# Altium schematic sheet Sheet1.SchDoc
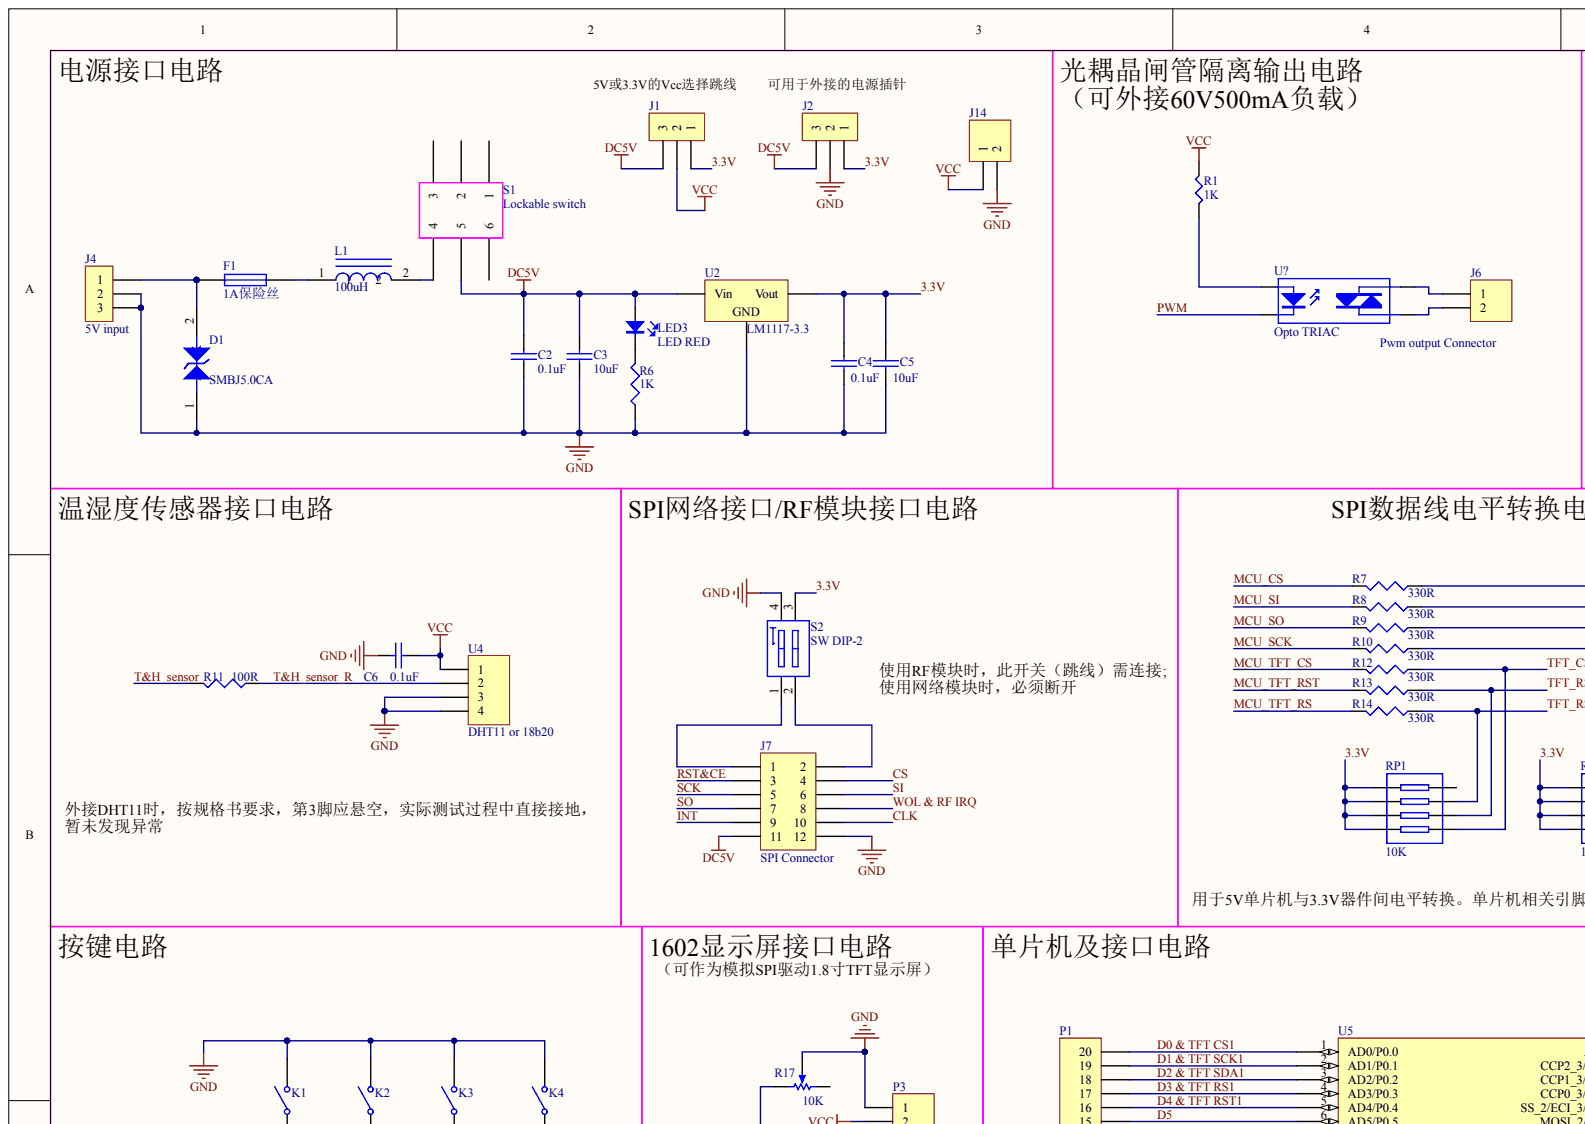
[diagram p0: Sheet1.SchDoc, top left]
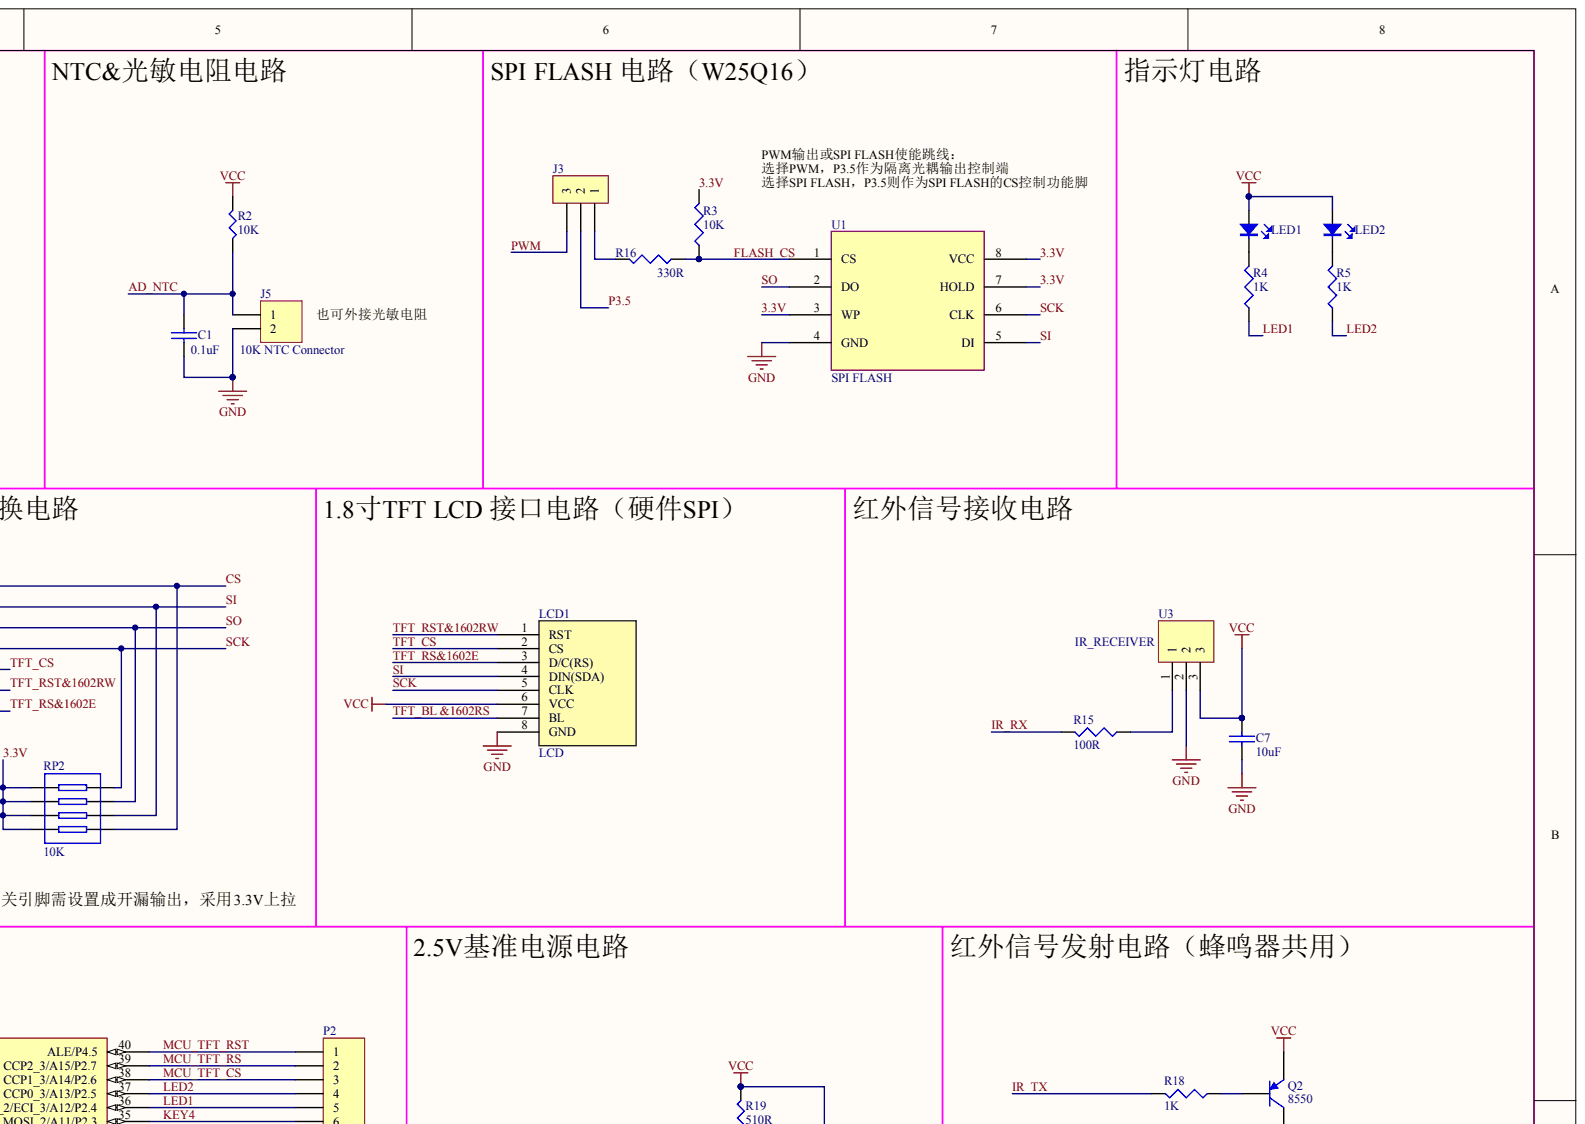
[diagram p1: Sheet1.SchDoc, top right]
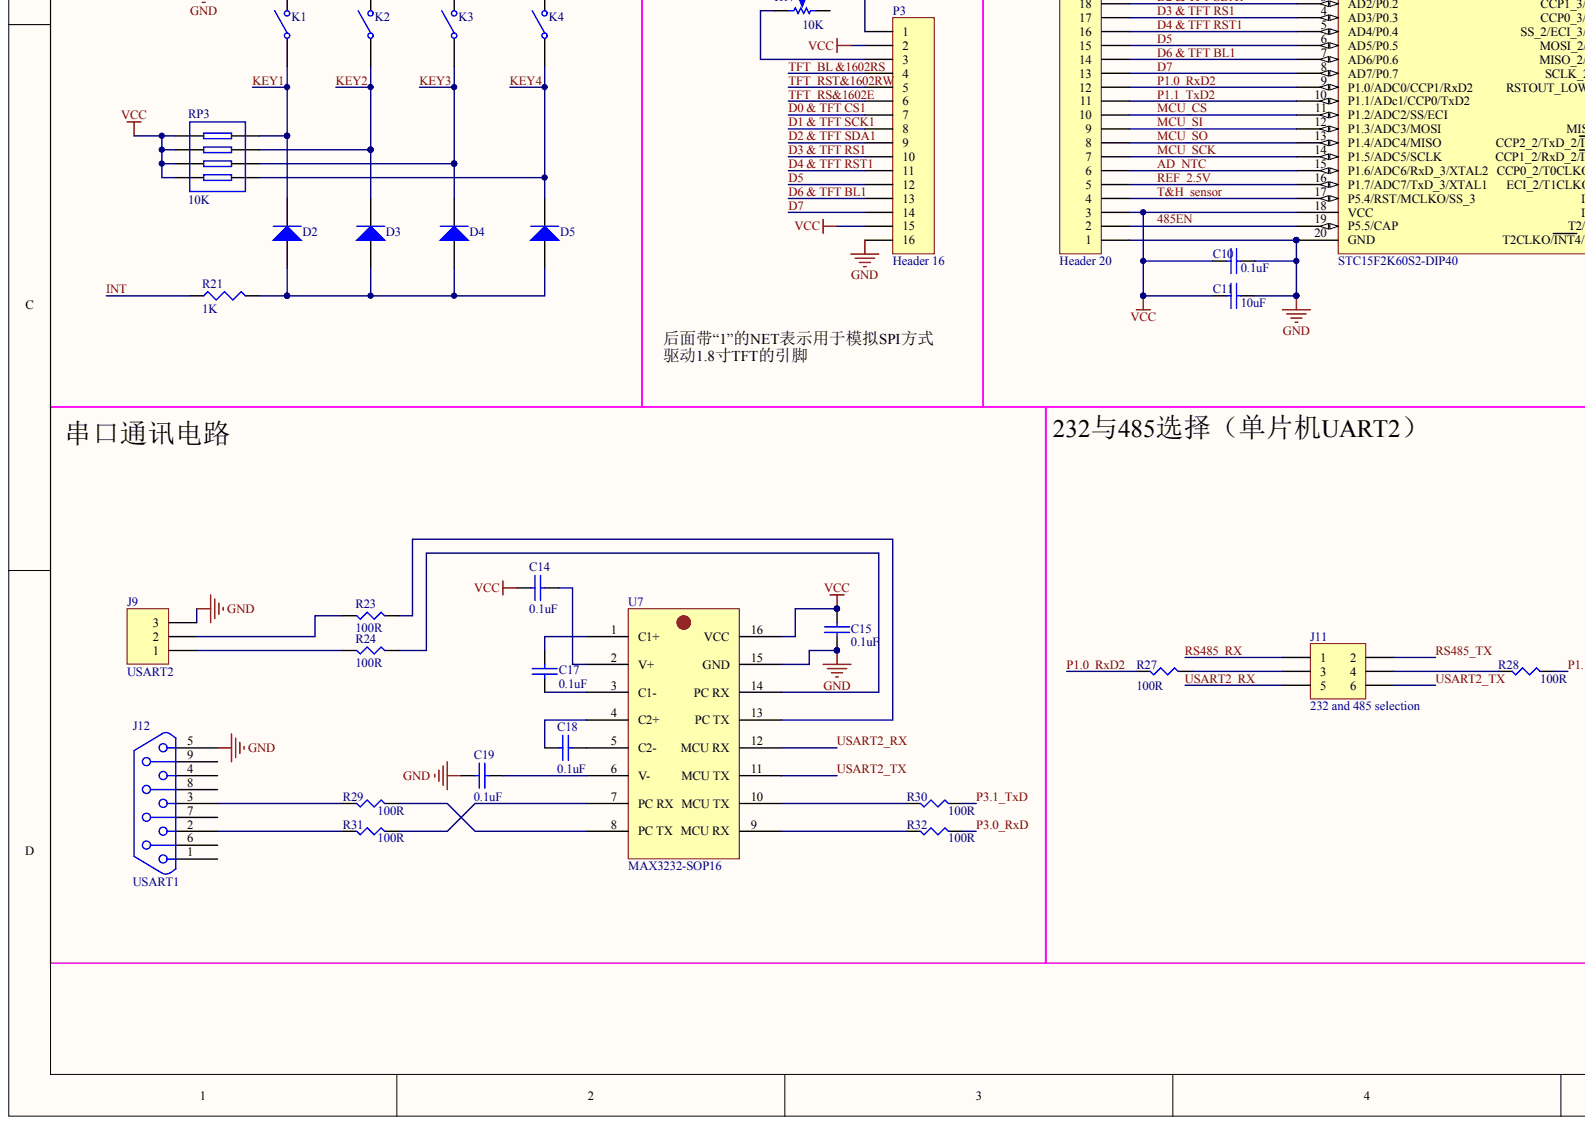
[diagram p2: Sheet1.SchDoc, bottom left]
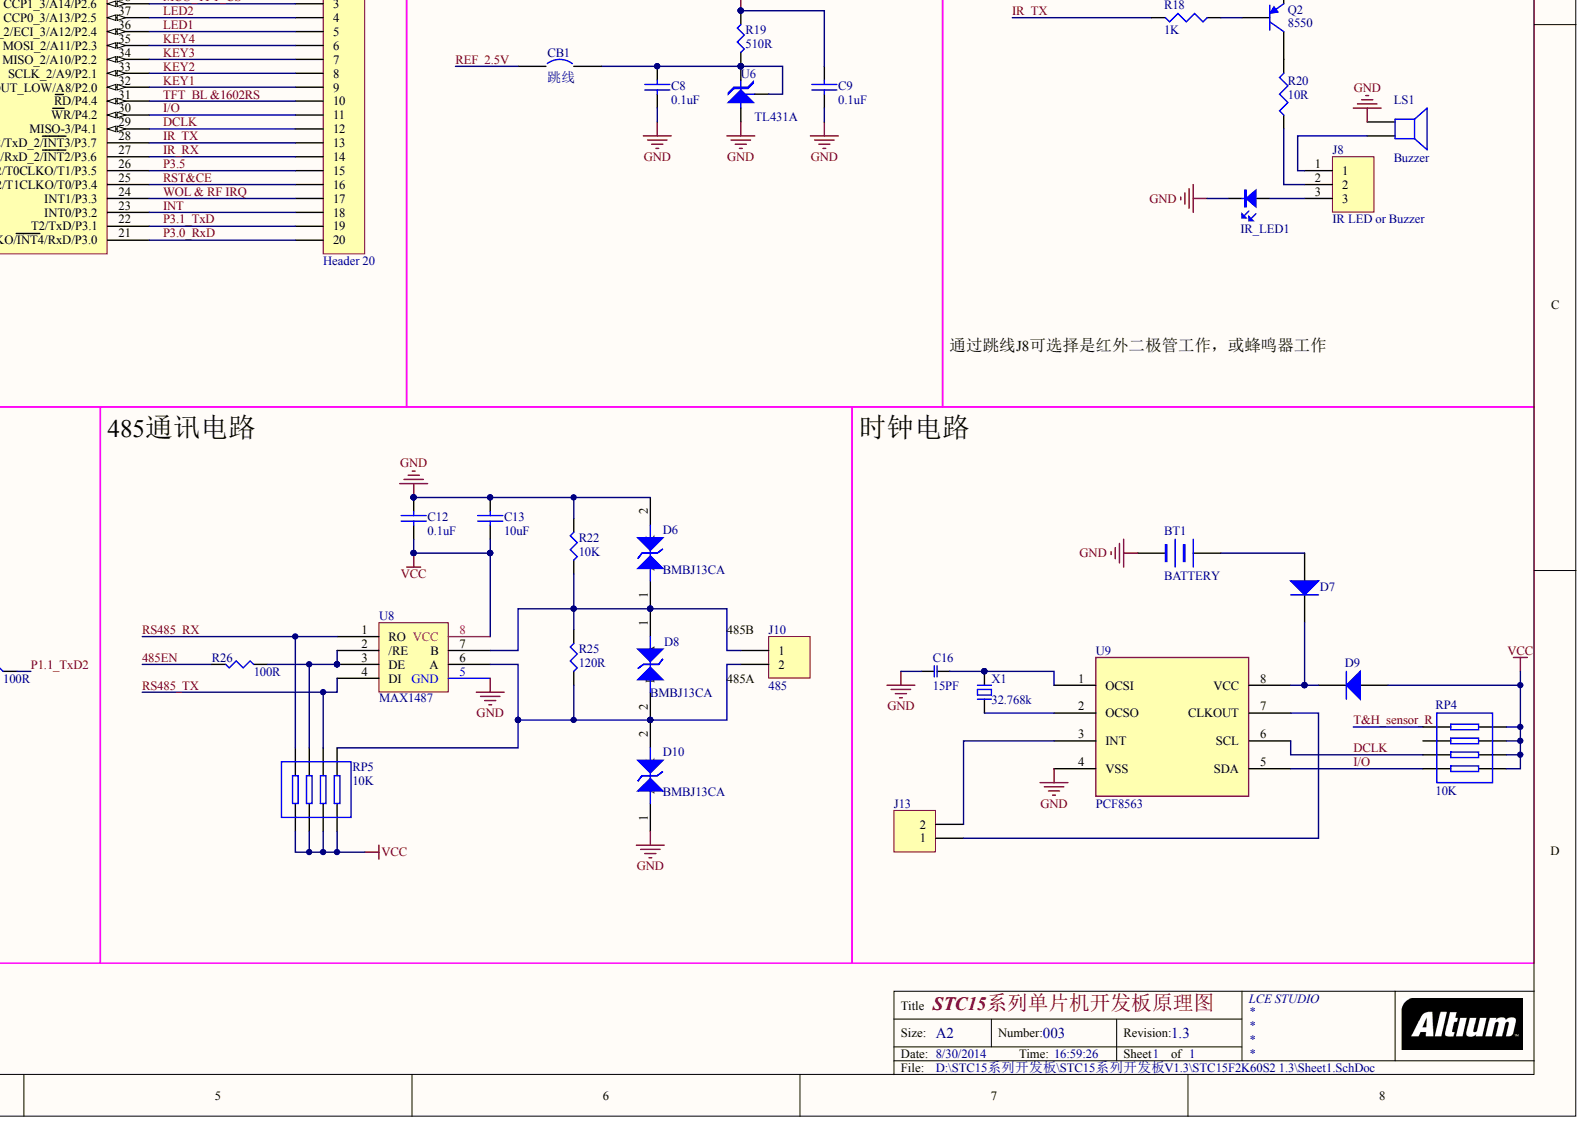
[diagram p3: Sheet1.SchDoc, bottom right]

## Parts
BT1  BATTERY
C1  0.1uF
C2  0.1uF
C3  10uF
C4  0.1uF
C5  10uF
C6  0.1uF
C7  10uF
C8  0.1uF
C9  0.1uF
C10  0.1uF
C11  10uF
C12  0.1uF
C13  10uF
C14  0.1uF
C15  0.1uF
C16  15PF
C17  0.1uF
C18  0.1uF
C19  0.1uF
CB1  跳线
D1  SMBJ5.0CA
D2  SS14  (value not drawn)
D3  SS14  (value not drawn)
D4  SS14  (value not drawn)
D5  SS14  (value not drawn)
D6  BMBJ13CA
D7  SS14  (value not drawn)
D8  BMBJ13CA
D9  SS14  (value not drawn)
D10  BMBJ13CA
F1  1A保险丝
IR_LED1  IR_LED
J1  (no value)
J2  (no value)
J3  (no value)
J4  5V input
J5  10K NTC Connector
J6  Pwm output Connector
J7  SPI Connector
J8  IR LED or Buzzer
J9  USART2
J10  485
J11  232 and 485 selection
J12  USART1
J13  (no value)
J14  (no value)
K1  SW-SPST  (value not drawn)
K2  SW-SPST  (value not drawn)
K3  SW-SPST  (value not drawn)
K4  SW-SPST  (value not drawn)
L1  100uH
LCD1  LCD
LED1  LED BLUE  (value not drawn)
LED2  LED BLUE  (value not drawn)
LED3  LED RED
LS1  Buzzer
P1  Header 20
P2  Header 20
P3  Header 16
Q2  8550
R1  1K
R2  10K
R3  10K
R4  1K
R5  1K
R6  1K
R7  330R
R8  330R
R9  330R
R10  330R
R11  100R
R12  330R
R13  330R
R14  330R
R15  100R
R16  330R
R17  value 10K
R18  1K
R19  510R
R20  10R
R21  1K
R22  10K
R23  100R
R24  100R
R25  120R
R26  100R
R27  100R
R28  100R
R29  100R
R30  100R
R31  100R
R32  100R
RP1  10K
RP2  10K
RP3  10K
RP4  10K
RP5  10K
S1  Lockable switch
S2  SW DIP-2
U1  SPI FLASH
U2  LM1117-3.3
U3  IR_RECEIVER
U4  DHT11 or 18b20
U5  STC15F2K60S2-DIP40
U6  TL431A
U7  MAX3232-SOP16
U8  MAX1487
U9  PCF8563
U?  Opto TRIAC
X1  32.768k

## Nets
DC5V: C2.2 C3.2 J1.3 J2.3 J7.11 LED3.A S1.5 U2.3
GND: BT1.2 C1.1 C2.1 C3.1 C4.1 C5.1 C6.2 C7.2 C8.1 C9.1 C10.2 C11.2 C12.2 C13.2 C15.2 C16.1 C19.2 D1.1 D6.2 D10.1 IR_LED1.K J2.2 J4.2 J4.3 J5.2 J7.12 J9.3 J12.5 J14.2 K1.2 K2.2 K3.2 K4.2 LCD1.8(GND) LS1.1 P1.1 P3.1 P3.16 R6.1 R17.3 R22.2 S2.4 U1.4(GND) U2.1 U3.2 U4.3 U4.4 U5.20(GND) U6.3 U7.15(GND) U8.5(GND) U9.4(VSS)
VCC: C6.1 C7.1 C9.2 C10.1 C11.1 C12.1 C13.1 C14.2 C15.1 D9.1 J1.2 J14.1 LCD1.6(VCC) LED1.A LED2.A P1.3 P3.2 P3.15 Q2.1 R1.1 R2.1 R19.1 RP3.5 RP3.6 RP3.7 RP3.8 RP4.5 RP4.6 RP4.7 RP4.8 RP5.1 RP5.2 RP5.3 RP5.4 U3.3 U4.1 U5.18(VCC) U7.16(VCC) U8.8(VCC)
3.3V: C4.2 C5.2 J1.1 J2.1 R3.2 RP1.1 RP1.2 RP1.3 RP1.4 RP2.5 RP2.6 RP2.7 RP2.8 S2.3 U1.3(WP) U1.7(HOLD) U1.8(VCC) U2.2
485EN: P1.2 R26.2 U5.19(P5.5/CAP)
AD_NTC: C1.2 J5.1 P1.6 R2.2 U5.15(P1.6/ADC6/RxD_3/XTAL2)
CLK: J7.10
CS: J7.4 R7.1 RP2.1
D0 & TFT CS1: P1.20 P3.7 U5.1(AD0/P0.0)
D1 & TFT SCK1: P1.19 P3.8 U5.2(AD1/P0.1)
D2 & TFT SDA1: P1.18 P3.9 U5.3(AD2/P0.2)
D3 & TFT RS1: P1.17 P3.10 U5.4(AD3/P0.3)
D4 & TFT RST1: P1.16 P3.11 U5.5(AD4/P0.4)
D5: P1.15 P3.12 U5.6(AD5/P0.5)
D6 & TFT BL1: P1.14 P3.13 U5.7(AD6/P0.6)
D7: P1.13 P3.14 U5.8(AD7/P0.7)
DCLK: P2.12 RP4.3 U5.29(MISO-3/P4.1) U9.6(SCL)
FLASH_CS: R3.1 R16.1 U1.1(CS)
I/O: P2.11 RP4.4 U5.30(WR/P4.2) U9.5(SDA)
INT: J7.9 P2.18 R21.1 U5.23(INT0/P3.2)
IR_RX: P2.14 R15.2 U5.27(CCP1_2/RxD_2/INT2/P3.6)
IR_TX: P2.13 R18.2 U5.28(CCP2_2/TxD_2/INT3/P3.7)
KEY1: D2.2 K1.1 P2.9 RP3.4 U5.32(RSTOUT_LOW/A8/P2.0)
KEY2: D3.2 K2.1 P2.8 RP3.3 U5.33(SCLK_2/A9/P2.1)
KEY3: D4.2 K3.1 P2.7 RP3.2 U5.34(MISO_2/A10/P2.2)
KEY4: D5.2 K4.1 P2.6 RP3.1 U5.35(MOSI_2/A11/P2.3)
LED1: P2.5 R4.1 U5.36(SS_2/ECI_3/A12/P2.4)
LED2: P2.4 R5.1 U5.37(CCP0_3/A13/P2.5)
MCU_CS: P1.10 R7.2 U5.11(P1.2/ADC2/SS/ECI)
MCU_SCK: P1.7 R10.2 U5.14(P1.5/ADC5/SCLK)
MCU_SI: P1.9 R8.2 U5.12(P1.3/ADC3/MOSI)
MCU_SO: P1.8 R9.2 U5.13(P1.4/ADC4/MISO)
MCU_TFT_CS: P2.3 R12.2 U5.38(CCP1_3/A14/P2.6)
MCU_TFT_RS: P2.2 R14.2 U5.39(CCP2_3/A15/P2.7)
MCU_TFT_RST: P2.1 R13.2 U5.40(ALE/P4.5)
P1.0_RXD2: P1.12 R27.2 U5.9(P1.0/ADC0/CCP1/RxD2)
P1.1_TXD2: P1.11 R28.1 U5.10(P1.1/ADc1/CCP0/TxD2)
P3.0_RXD: P2.20 R32.1 U5.21(T2CLKO/INT4/RxD/P3.0)
P3.1_TXD: P2.19 R30.1 U5.22(T2/TxD/P3.1)
P3.5: J3.2 P2.15 U5.26(CCP0_2/T0CLKO/T1/P3.5)
PWM: J3.3 U?.2
REF_2.5V: CB1.1 P1.5 U5.16(P1.7/ADC7/TxD_3/XTAL1)
RS485_RX: J11.1 RP5.5 U8.1(RO)
RS485_TX: J11.2 RP5.7 U8.4(DI)
RST&CE: J7.3 P2.16 U5.25(ECI_2/T1CLKO/T0/P3.4)
SCK: J7.5 LCD1.5(CLK) R10.1 RP2.4 U1.6(CLK)
SI: J7.6 LCD1.4(DIN(SDA)) R8.1 RP2.2 U1.5(DI)
SO: J7.7 R9.1 RP2.3 U1.2(DO)
T&H_SENSOR: P1.4 R11.2 U5.17(P5.4/RST/MCLKO/SS_3)
T&H_SENSOR_R: R11.1 RP4.1 U4.2
TFT_BL &1602RS: LCD1.7(BL) P2.10 P3.4 U5.31(RD/P4.4)
TFT_CS: LCD1.2(CS) R12.1 RP1.8
TFT_RS&1602E: LCD1.3(D/C(RS)) P3.6 R14.1 RP1.6
TFT_RST&1602RW: LCD1.1(RST) P3.5 R13.1 RP1.7
USART2_RX: J11.5 U7.12(MCU_RX)
USART2_TX: J11.6 U7.11(MCU_TX)
WOL & RF IRQ: J7.8 P2.17 U5.24(INT1/P3.3)
NetBT1_1: BT1.1 D7.1
NetC8_2: C8.2 CB1.2 R19.2 U6.1 U6.2
NetC14_1: C14.1 U7.2(V+)
NetC16_2: C16.2 U9.1(OCSI) X1.2
NetC17_1: C17.1 U7.1(C1+)
NetC17_2: C17.2 U7.3(C1-)
NetC18_1: C18.1 U7.4(C2+)
NetC18_2: C18.2 U7.5(C2-)
NetC19_1: C19.1 U7.6(V-)
NetD1_2: D1.2 F1.1 J4.1
NetD2_1: D2.1 D3.1 D4.1 D5.1 R21.2
NetD6_1: D6.1 D8.1 J10.1 R22.1 R25.2 U8.7(B)
NetD7_2: D7.2 D9.2 U9.8(VCC)
NetD8_2: D8.2 D10.2 J10.2 R25.1 RP5.8 U8.6(A)
NetF1_2: F1.2 L1.1
NetIR_LED1_A: IR_LED1.A J8.3
NetJ3_1: J3.1 R16.2
NetJ6_1: J6.1 U?.3
NetJ6_2: J6.2 U?.4
NetJ7_1: J7.1 S2.1
NetJ7_2: J7.2 S2.2
NetJ8_1: J8.1 LS1.2
NetJ8_2: J8.2 R20.1
NetJ9_1: J9.1 R24.1
NetJ9_2: J9.2 R23.1
NetJ11_3: J11.3 R27.1
NetJ11_4: J11.4 R28.2
NetJ12_2: J12.2 R31.1
NetJ12_3: J12.3 R29.1
NetJ13_1: J13.1 U9.7(CLKOUT)
NetJ13_2: J13.2 U9.3(INT)
NetL1_2: L1.2 S1.4
NetLED1_K: LED1.K R4.2
NetLED2_K: LED2.K R5.2
NetLED3_K: LED3.K R6.2
NetP3_3: P3.3 R17.2
NetQ2_2: Q2.2 R18.1
NetQ2_3: Q2.3 R20.2
NetR1_2: R1.2 U?.1
NetR15_1: R15.1 U3.1
NetR23_2: R23.2 U7.13(PC_TX)
NetR24_2: R24.2 U7.14(PC_RX)
NetR26_1: R26.1 RP5.6 U8.2(/RE) U8.3(DE)
NetR29_2: R29.2 U7.8(PC_TX)
NetR30_2: R30.2 U7.10(MCU_TX)
NetR31_2: R31.2 U7.7(PC_RX)
NetR32_2: R32.2 U7.9(MCU_RX)
NetU9_2: U9.2(OCSO) X1.1

Not connected: J12 1 4 6 7 8 9; R17 1; RP1 5; RP4 2; S1 1 2 3 6

## Library data of the parts
R17 = (no symbol name): Sim Note: Enter the Resistance value and the set point into parameter fields.; Set Position: 0.5; Published: 8-Jun-2000; LatestRevisionDate: 17-Jul-2002; LatestRevisionNote: Re-released for DXP Platform.; PackageReference: VR5; PackageDescription: Variable Resistor, Thru-Hole; 3 Leads; Publisher: Altium Limited
BT1 C1-C19 CB1 D1-D10 F1 IR_LED1 J1-J14 K1-K4 L1 LCD1 LED1-LED3 LS1 P1-P3 Q2 R1-R16 R18-R32 RP1-RP5 S1 S2 U1-U9 U? X1 = (no symbol name)
  CB1 K1-K4: Published: 24-Mar-1999; LatestRevisionDate: 17-Jul-2002; LatestRevisionNote: Re-released for DXP Platform.; PackageReference: SPST-2; PackageDescription: Switch; 2 Leads
  D2-D5 D7 D9: Published: 8-Jun-2000; LatestRevisionDate: 17-Jul-2002; LatestRevisionNote: Re-released for DXP Platform.; PackageVersion: Sep-1996; PackageReference: SMC; PackageDescription: DO-214-AB/SMC; 2 C-Bend Leads; Body 7.9 x 5.9 mm, inc. leads (LxW); Code_JEDEC: DO-214-AB
  F1: Published: 8-Jun-2000; LatestRevisionDate: 17-Jul-2002; LatestRevisionNote: Re-released for DXP Platform.; PackageReference: PIN-W2/E2.8; PackageDescription: Thru-Hole; 2 Leads
  J5-J11 J13 J14 P1-P3 U3 U4: LatestRevisionDate: 17-Jul-2002; LatestRevisionNote: Re-released for DXP Platform.
  LS1: Published: 24-Mar-1999; LatestRevisionDate: 17-Jul-2002; LatestRevisionNote: Re-released for DXP Platform.; PackageReference: PIN2
  Q2: Published: 8-Jun-2000; LatestRevisionDate: 15-Jan-2003; LatestRevisionNote: PCB Footprint 'BCY-W3/D4.7' replaced; DatasheetVersion: 1997; PackageVersion: Sep-1998; PackageReference: TO-92A; PackageDescription: TO, Flat Index; 3 In-Line, Axial Leads; Body Dia. 4.5mm; Leads 0.51 x 0.48 mm (max); ComponentLink1Description: Manufacturer Link; ComponentLink1URL: http://www.fairchildsemi.com/; ComponentLink2Description: Datasheet; ComponentLink2URL: http://www.fairchildsemi.com/ds/2N%2F2N3906.pdf
  S2: Published: 24-Mar-1999; LatestRevisionDate: 17-Jul-2002; LatestRevisionNote: Re-released for DXP Platform.; PackageReference: DIP-4
  U2: Published: 24-Mar-1999; LatestRevisionDate: 29-May-2009; LatestRevisionNote: IPC-7351 Footprint Added.; PackageReference: D2PAK; PackageDescription: 3-Pin Transistor Outline Package 2.54 mm Pitch, 15.1 mm Lead Span
  U?: Published: 24-Mar-1999; LatestRevisionDate: 17-Jul-2002; LatestRevisionNote: Re-released for DXP Platform.; PackageReference: NPSIP4A; PackageDescription: SIP; 4 Leads; Body 10 x 11.5 x 7.5 mm (typ); Pitch 2.54 mm
  CB1 D2-D5 D7 D9 F1 J5-J11 J13 J14 K1-K4 LS1 P1-P3 Q2 S2 U2-U4 U?: Publisher: Altium Limited
